# Altium schematic sheet 02_CORE.SchDoc
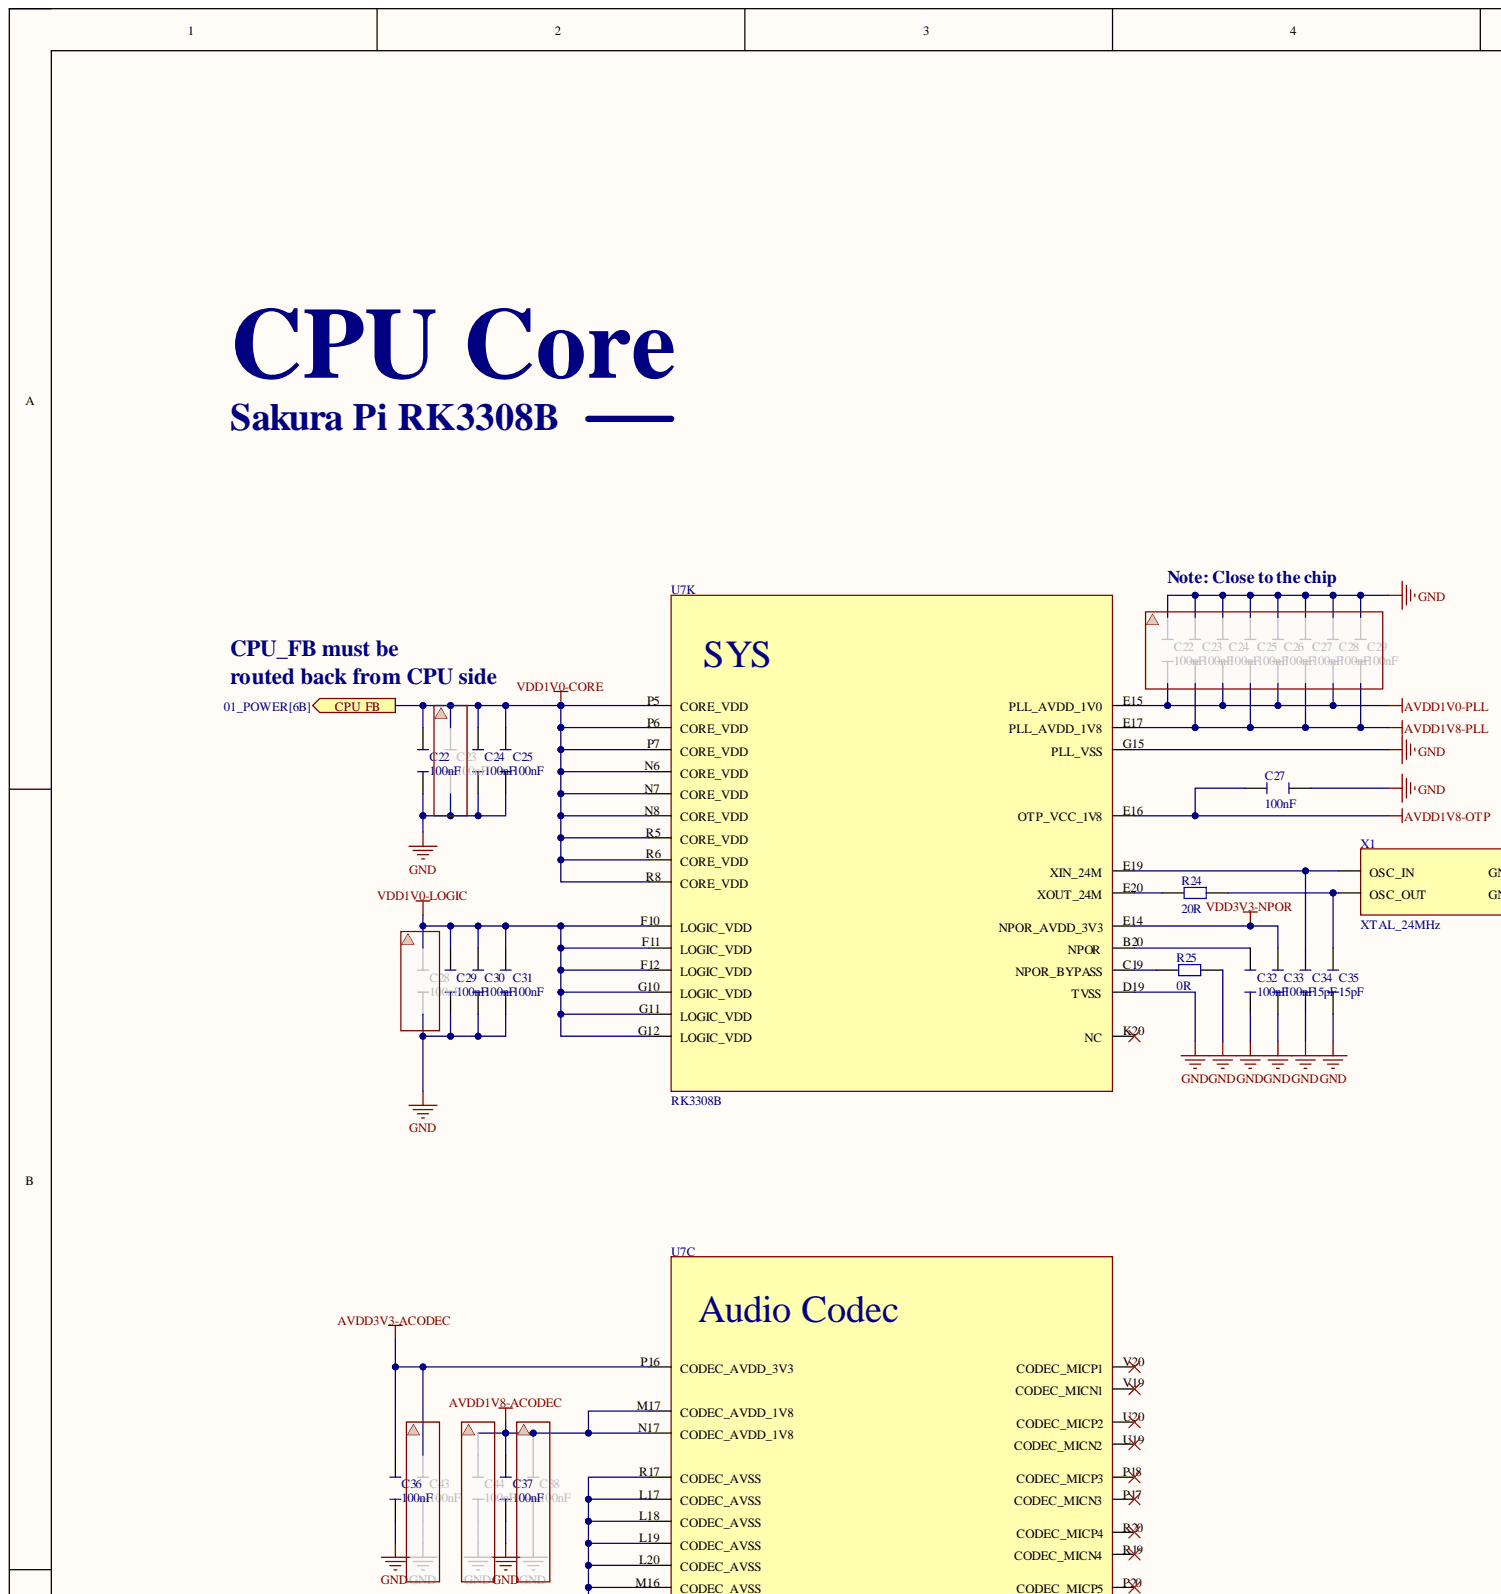
[diagram p0: 02_CORE.SchDoc, top left]
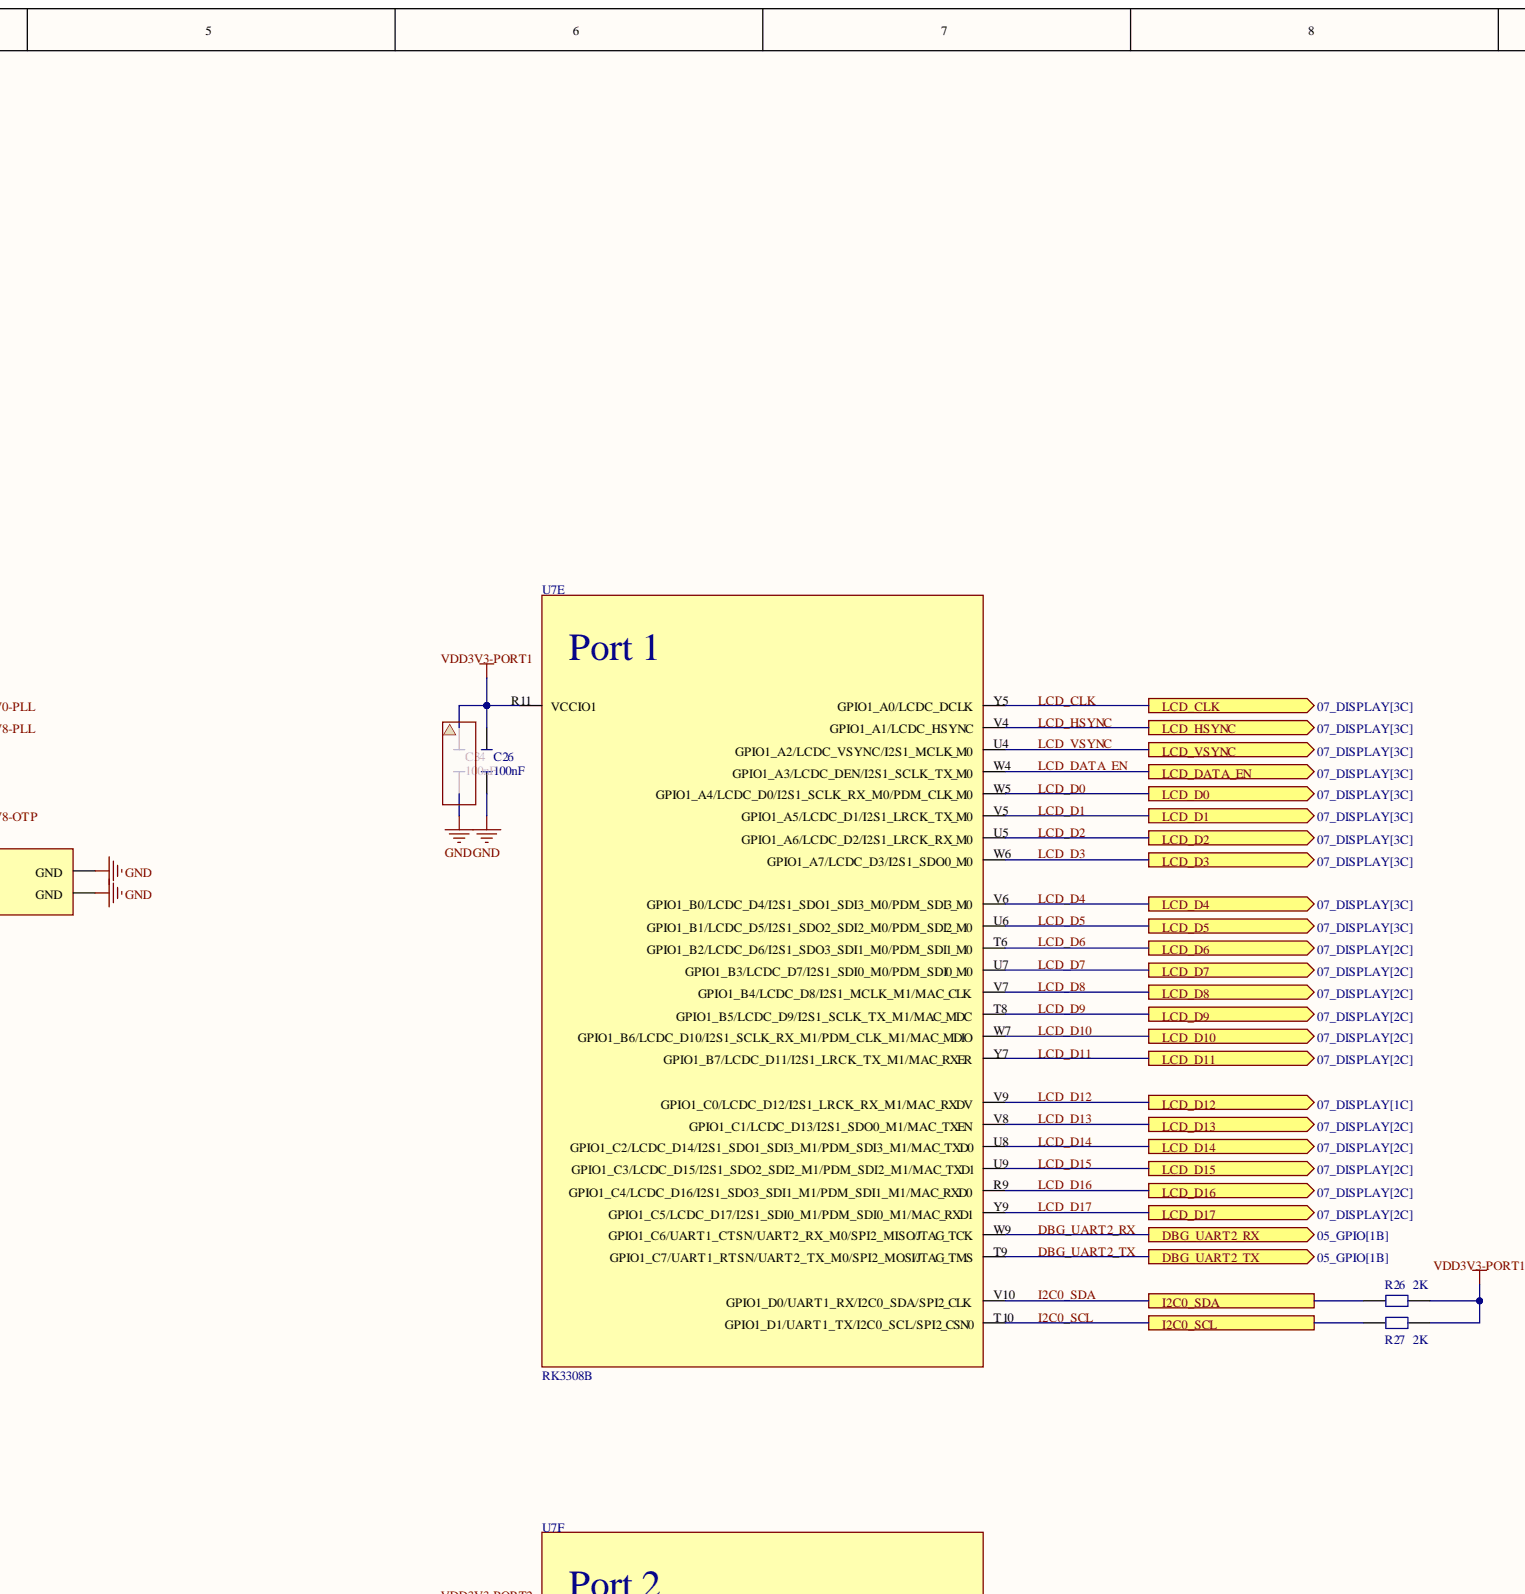
[diagram p1: 02_CORE.SchDoc, top centre]
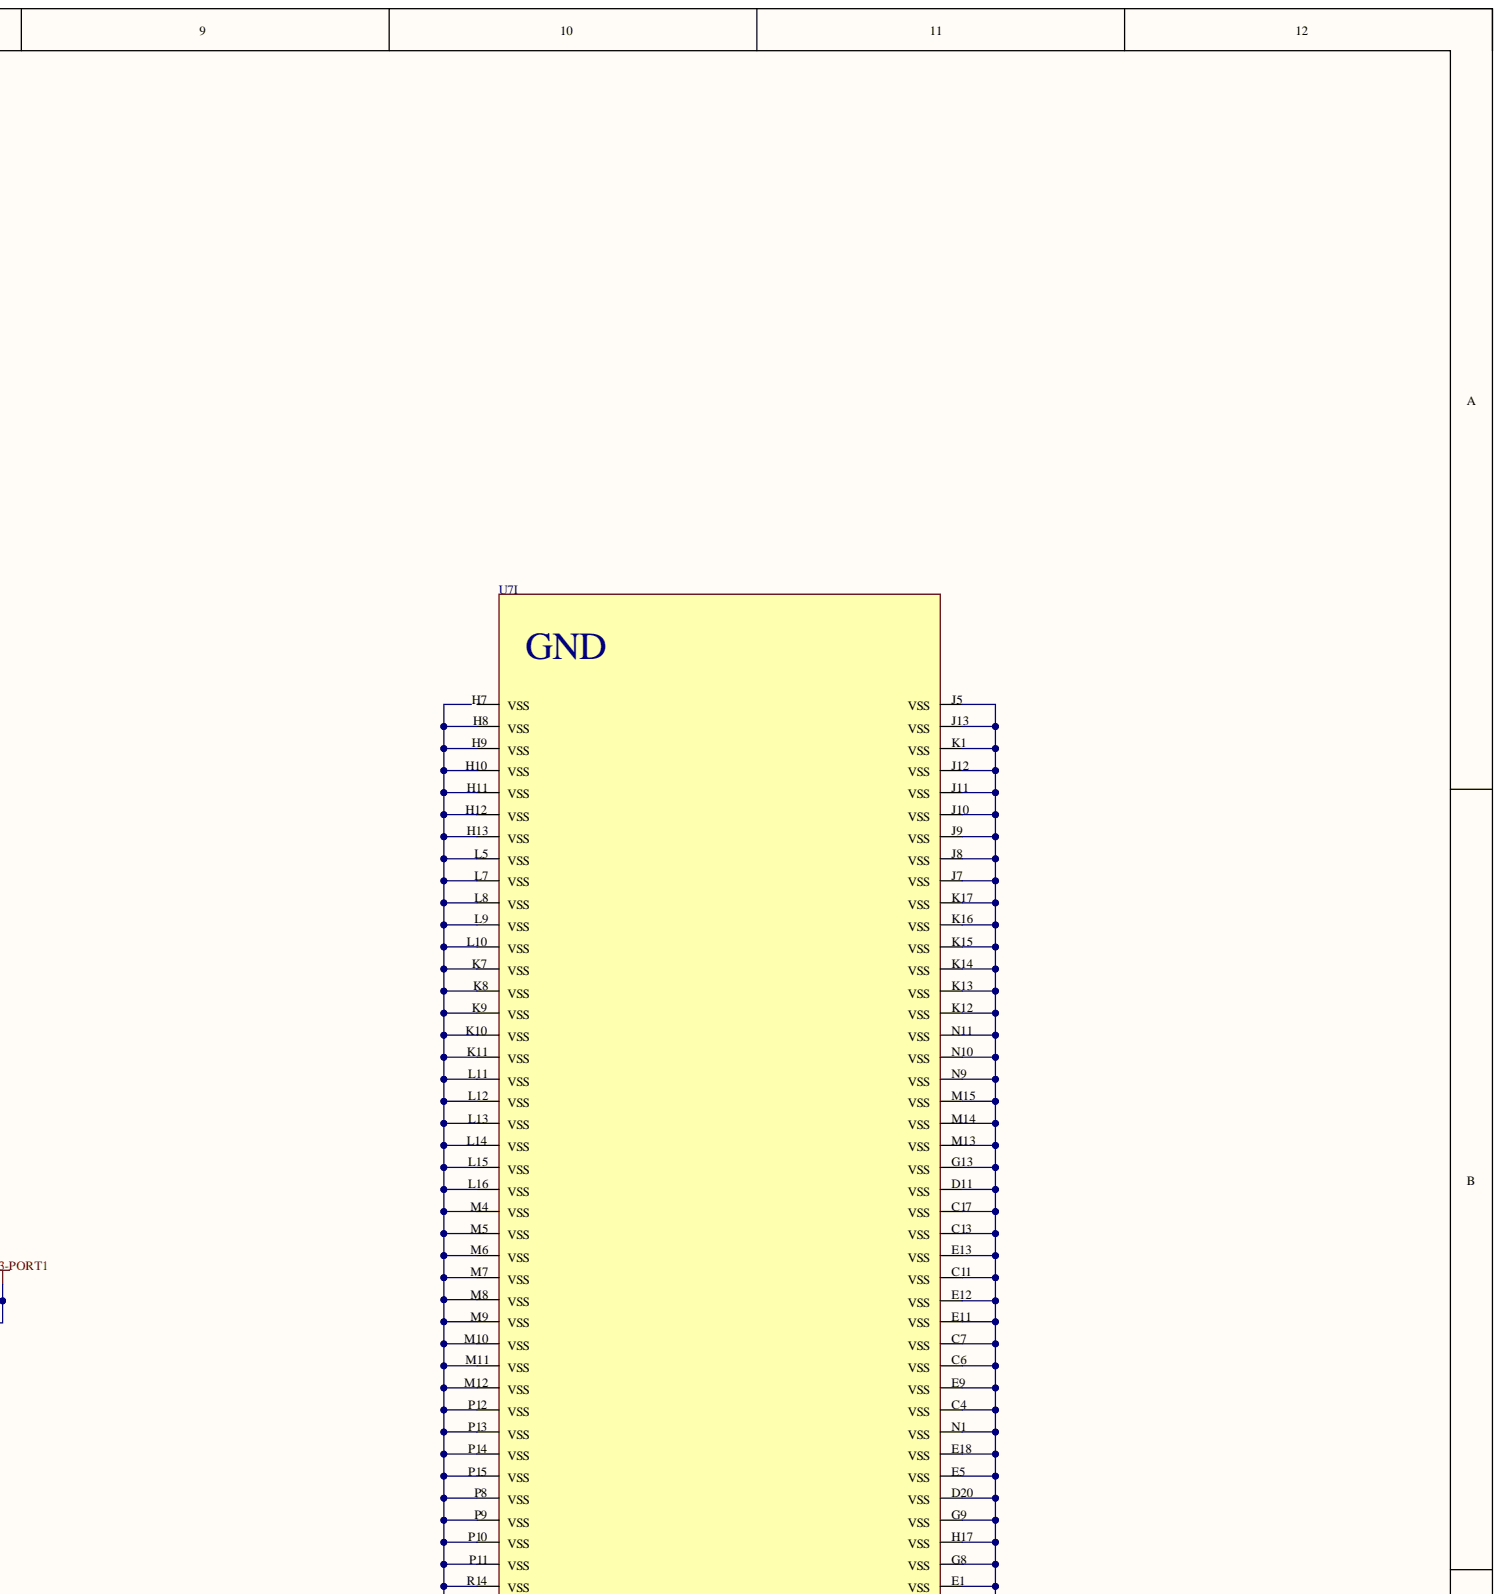
[diagram p2: 02_CORE.SchDoc, top right]
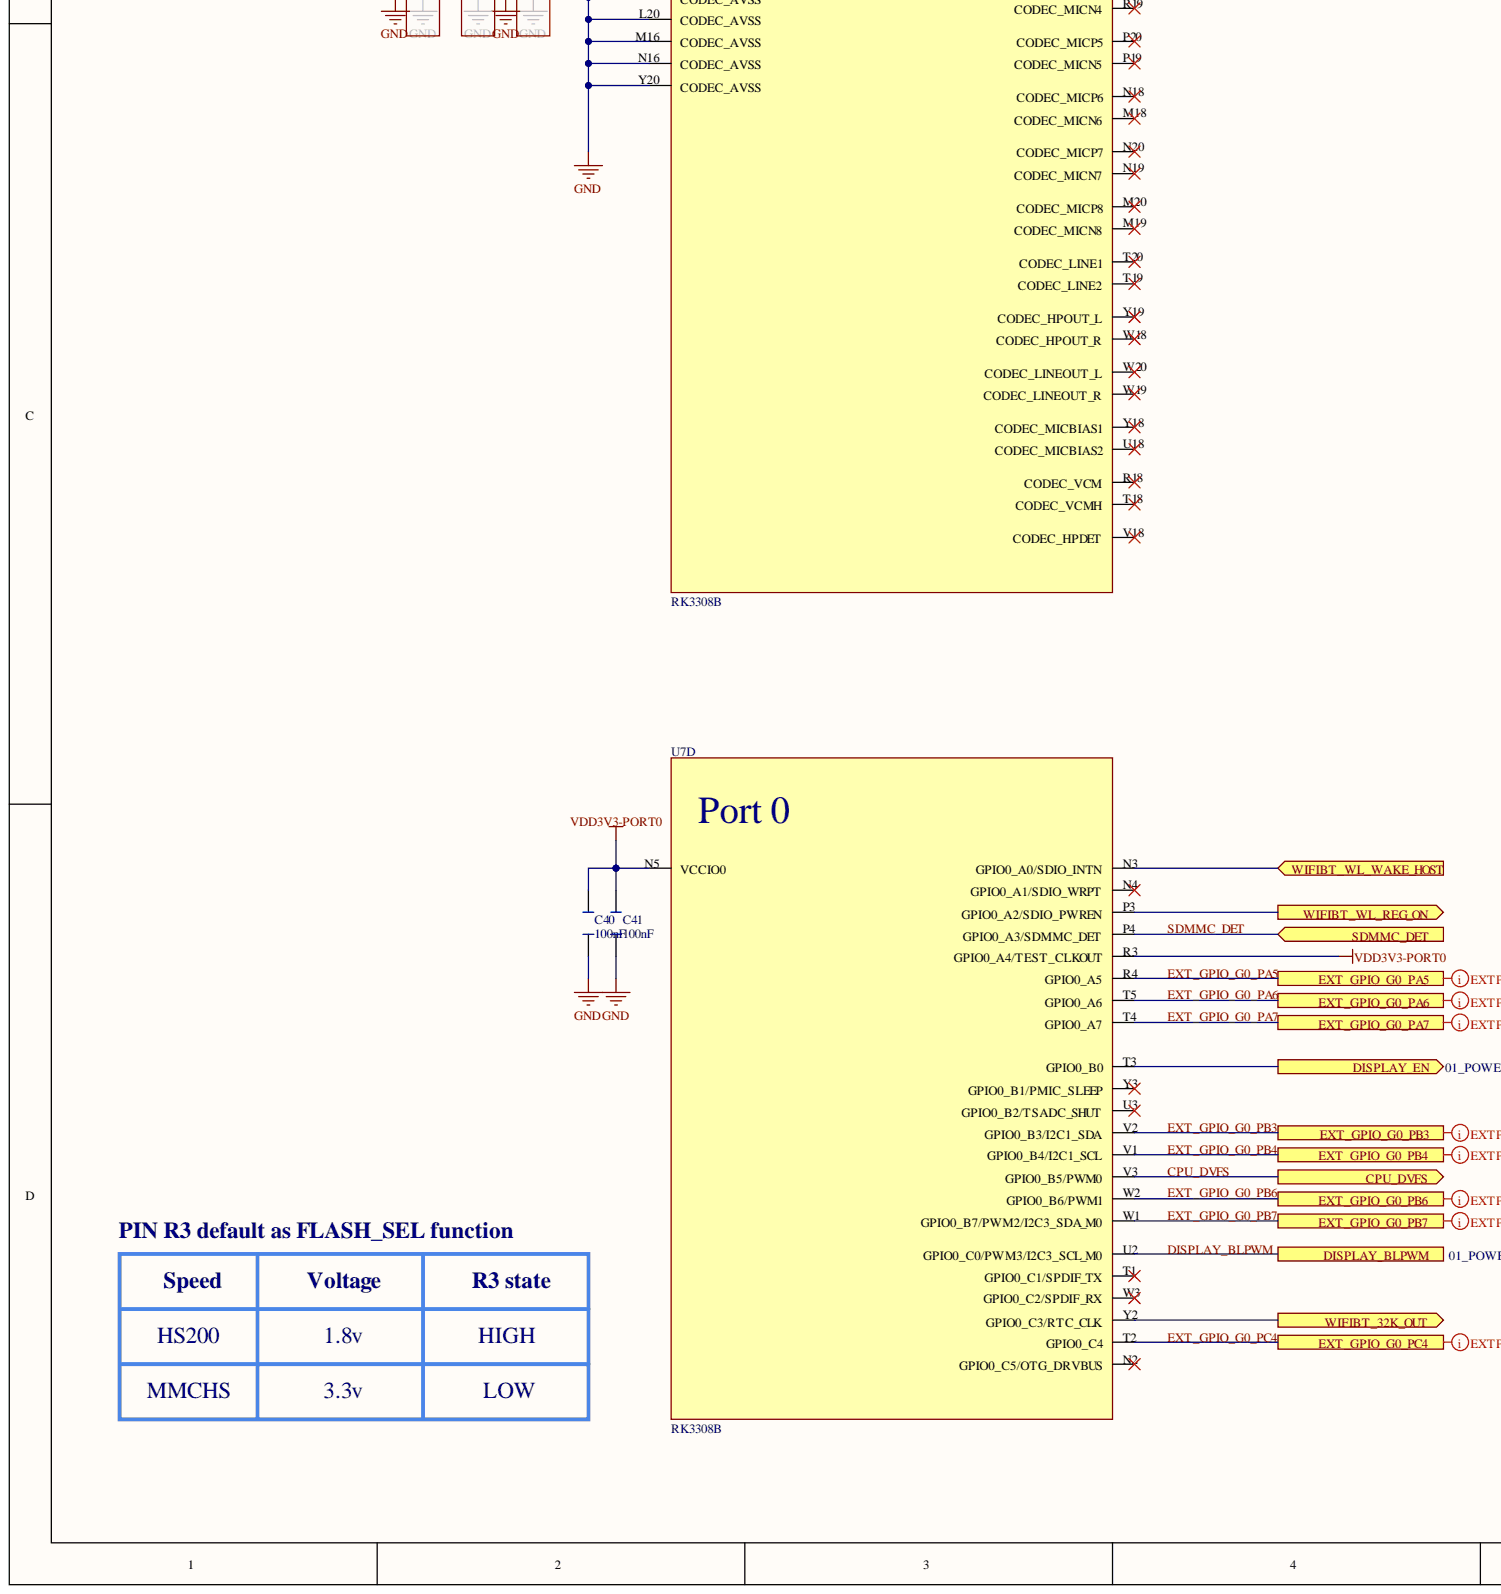
[diagram p3: 02_CORE.SchDoc, bottom left]
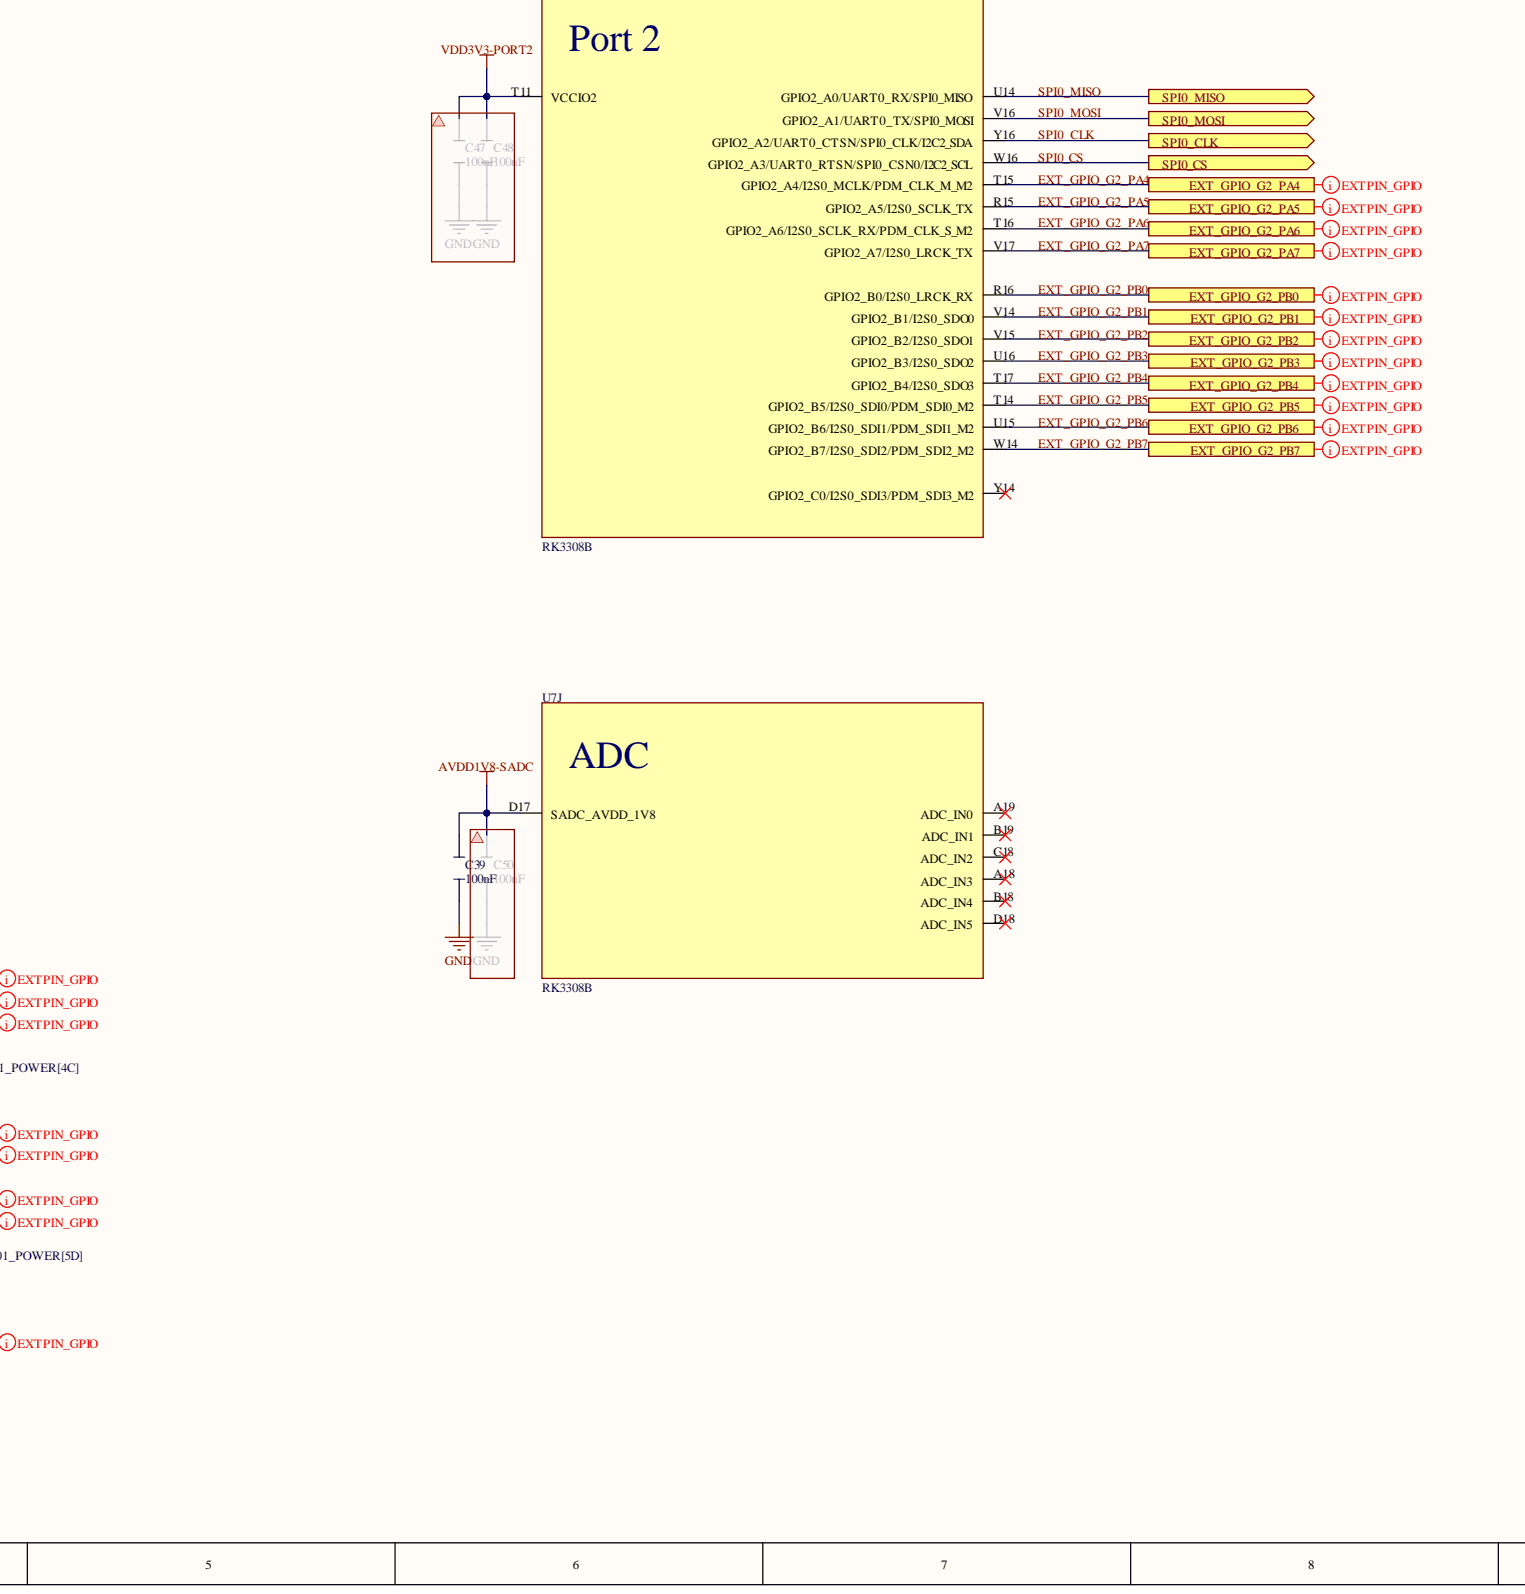
[diagram p4: 02_CORE.SchDoc, bottom centre]
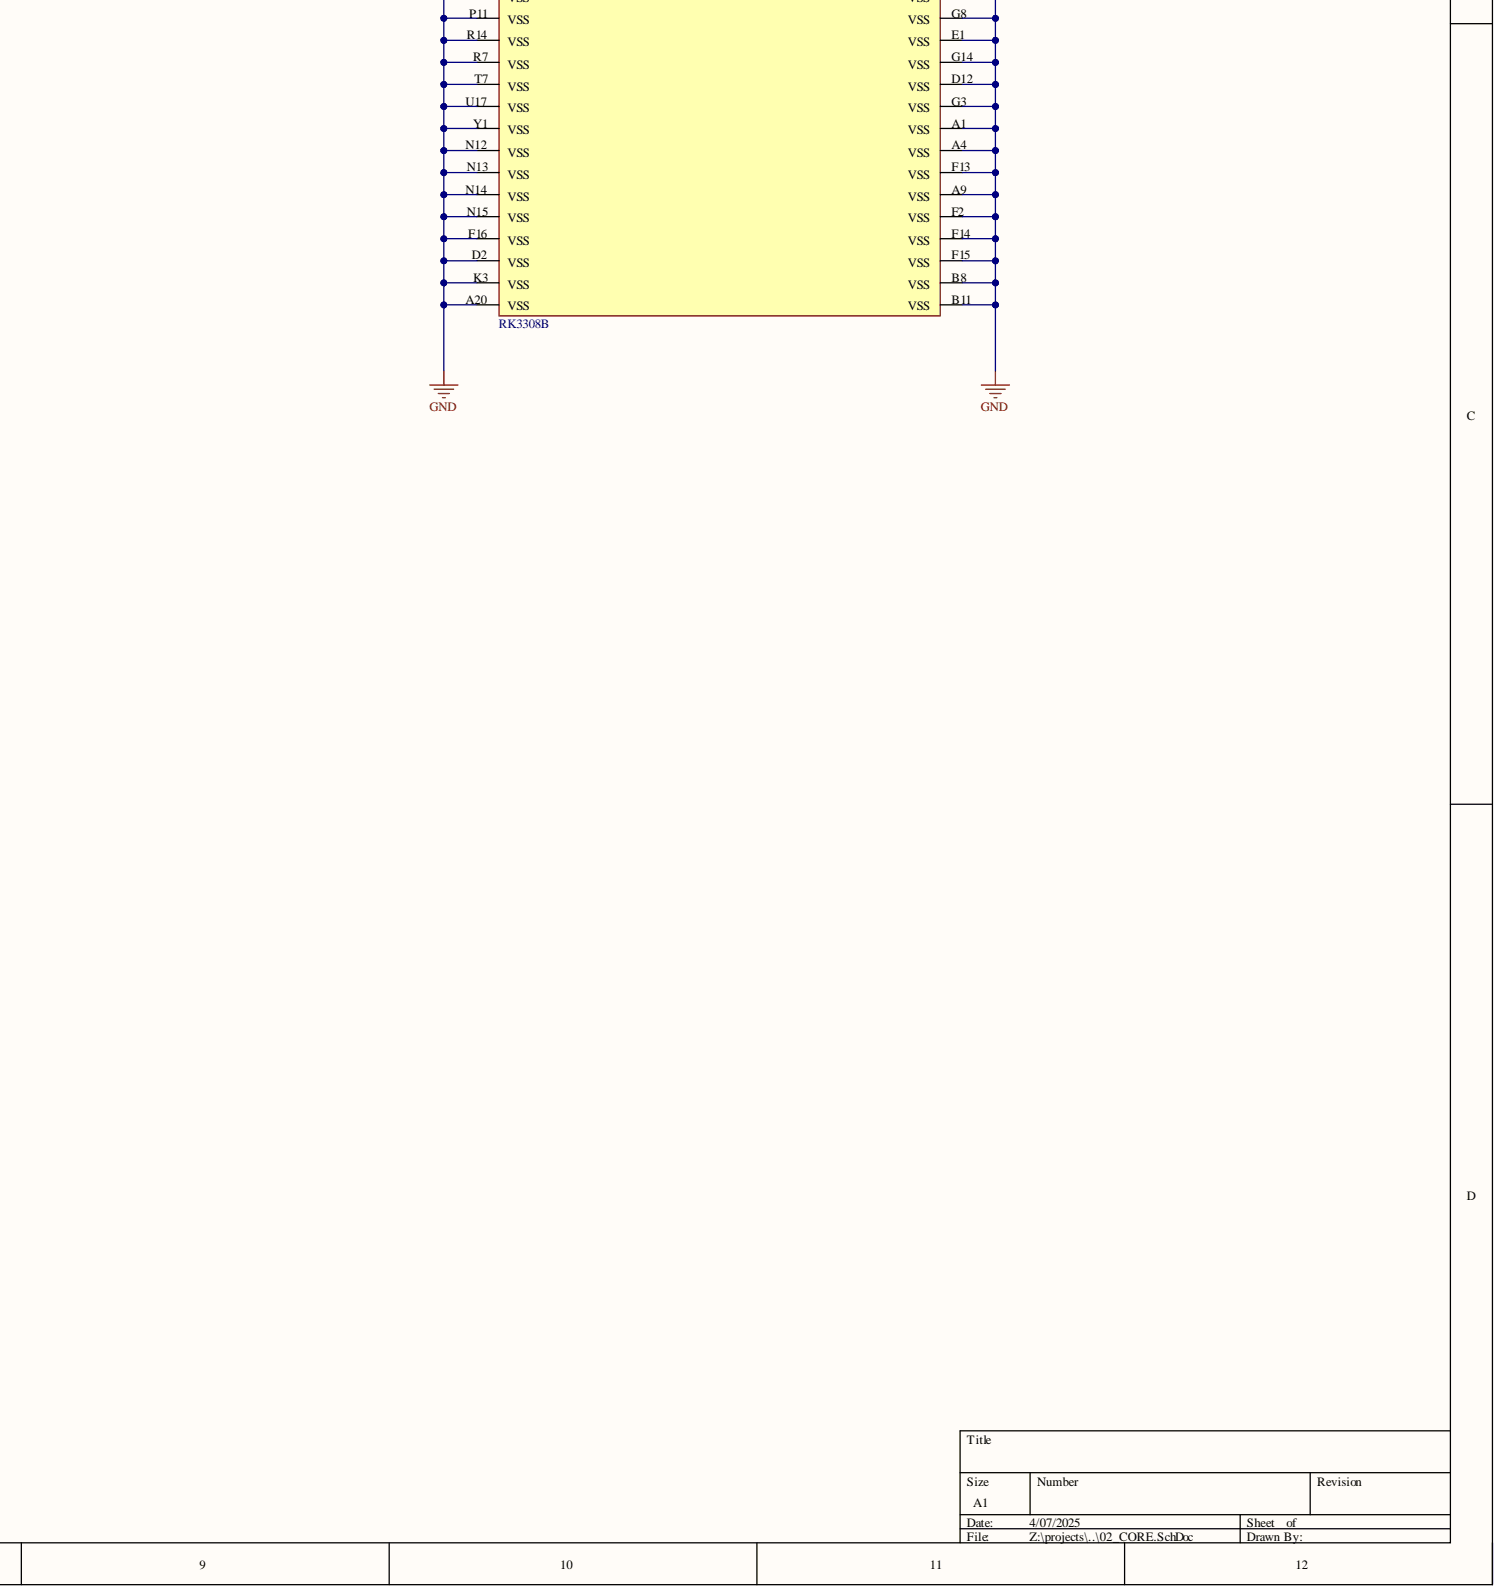
[diagram p5: 02_CORE.SchDoc, bottom right]

## Parts
C22  100nF  [C0402]
C24  100nF  [C0402]
C25  100nF  [C0402]
C26  100nF  [C0402]
C27  100nF  [C0402]
C29  100nF  [C0402]
C30  100nF  [C0402]
C31  100nF  [C0402]
C32  100nF  [C0402]
C33  100nF  [C0402]
C34  15pF  [C0402]
C35  15pF  [C0402]
C36  100nF  [C0402]
C37  100nF  [C0402]
C39  100nF  [C0402]
C40  100nF  [C0402]
C41  100nF  [C0402]
R24  20R  [R0402]
R25  0R  [R0402]
R26  2K  [R0402]
R27  2K  [R0402]
U7  RK3308B  [RK3308B]  (parts C,D,E,F,I,J,K)
X1  XTAL_24MHz  [SMD2016]

## Ports
CPU_DVFS, CPU_FB, DBG_UART2_RX, DBG_UART2_TX, DISPLAY_BLPWM, DISPLAY_EN, EXT_GPIO_G0_PA5, EXT_GPIO_G0_PA6, EXT_GPIO_G0_PA7, EXT_GPIO_G0_PB3, EXT_GPIO_G0_PB4, EXT_GPIO_G0_PB6, EXT_GPIO_G0_PB7, EXT_GPIO_G0_PC4, EXT_GPIO_G2_PA4, EXT_GPIO_G2_PA5, EXT_GPIO_G2_PA6, EXT_GPIO_G2_PA7, EXT_GPIO_G2_PB0, EXT_GPIO_G2_PB1, EXT_GPIO_G2_PB2, EXT_GPIO_G2_PB3, EXT_GPIO_G2_PB4, EXT_GPIO_G2_PB5, EXT_GPIO_G2_PB6, EXT_GPIO_G2_PB7, I2C0_SCL, I2C0_SDA, LCD_CLK, LCD_D0, LCD_D1, LCD_D2, LCD_D3, LCD_D4, LCD_D5, LCD_D6, LCD_D7, LCD_D8, LCD_D9, LCD_D10, LCD_D11, LCD_D12, LCD_D13, LCD_D14, LCD_D15, LCD_D16, LCD_D17, LCD_DATA_EN, LCD_HSYNC, LCD_VSYNC, SDMMC_DET, SPI0_CLK, SPI0_CS, SPI0_MISO, SPI0_MOSI, WIFIBT_32K_OUT, WIFIBT_WL_REG_ON, WIFIBT_WL_WAKE_HOST

## Nets
AVDD1V0-PLL: U7.E15(PLL_AVDD_1V0)
AVDD1V8-ACODEC: C37.2 U7.M17(CODEC_AVDD_1V8) U7.N17(CODEC_AVDD_1V8)
AVDD1V8-OTP: C27.2 U7.E16(OTP_VCC_1V8)
AVDD1V8-PLL: U7.E17(PLL_AVDD_1V8)
AVDD1V8-SADC: C39.2 U7.D17(SADC_AVDD_1V8)
AVDD3V3-ACODEC: C36.2 U7.P16(CODEC_AVDD_3V3)
GND: C22.2 C24.2 C25.2 C26.1 C27.1 C29.2 C30.2 C31.2 C32.1 C33.2 C34.2 C35.2 C36.1 C37.1 C39.1 C40.1 C41.1 R25.2 U7.A1(VSS) U7.A4(VSS) U7.A9(VSS) U7.A20(VSS) U7.B8(VSS) U7.B11(VSS) U7.C4(VSS) U7.C6(VSS) U7.C7 U7.C11(VSS) U7.C13(VSS) U7.C17(VSS) U7.D2(VSS) U7.D11 U7.D12(VSS) U7.D19(TVSS) U7.D20(VSS) U7.E1(VSS) U7.E5(VSS) U7.E9(VSS) U7.E11(VSS) U7.E12(VSS) U7.E13(VSS) U7.E18(VSS) U7.F2(VSS) U7.F13(VSS) U7.F14(VSS) U7.F15(VSS) U7.F16(VSS) U7.G3(VSS) U7.G8(VSS) U7.G9(VSS) U7.G13(VSS) U7.G14(VSS) U7.G15(PLL_VSS) U7.H8(VSS) U7.H9(VSS) U7.H10(VSS) U7.H11(VSS) U7.H12(VSS) U7.H13(VSS) U7.H17(VSS) U7.J5 U7.J7 U7.J8 U7.J9(VSS) U7.J10(VSS) U7.J11(VSS) U7.J12(VSS) U7.J13(VSS) U7.K1(VSS) U7.K3(VSS) U7.K7(VSS) U7.K8(VSS) U7.K9(VSS) U7.K10 U7.K11(VSS) U7.K12(VSS) U7.K13(VSS) U7.K14(VSS) U7.K15(VSS) U7.K16(VSS) U7.K17(VSS) U7.L5 U7.L7 U7.L8(VSS) U7.L9(VSS) U7.L10(VSS) U7.L11(VSS) U7.L12(VSS) U7.L13(VSS) U7.L14(VSS) U7.L15(VSS) U7.L16 U7.L17(CODEC_AVSS) U7.L18(CODEC_AVSS) U7.L19(CODEC_AVSS) U7.L20(CODEC_AVSS) U7.M4(VSS) U7.M5(VSS) U7.M6(VSS) U7.M7(VSS) U7.M8(VSS) U7.M9(VSS) U7.M10 U7.M11(VSS) U7.M12(VSS) U7.M13(VSS) U7.M14(VSS) U7.M15(VSS) U7.M16(CODEC_AVSS) U7.N1(VSS) U7.N9(VSS) U7.N10(VSS) U7.N11 U7.N12(VSS) U7.N13(VSS) U7.N14(VSS) U7.N15(VSS) U7.N16(CODEC_AVSS) U7.P8(VSS) U7.P9(VSS) U7.P10(VSS) U7.P11(VSS) U7.P12(VSS) U7.P13(VSS) U7.P14(VSS) U7.P15(VSS) U7.R7(VSS) U7.R14(VSS) U7.R17(CODEC_AVSS) U7.T7(VSS) U7.U17(VSS) U7.Y1(VSS) U7.Y20(CODEC_AVSS) X1.2(GND) X1.4(GND)
VDD1V0-LOGIC: C29.1 C30.1 C31.1 U7.F10(LOGIC_VDD) U7.F11(LOGIC_VDD) U7.F12(LOGIC_VDD) U7.G10(LOGIC_VDD) U7.G11(LOGIC_VDD) U7.G12(LOGIC_VDD)
VDD3V3-NPOR: C33.1 U7.E14(NPOR_AVDD_3V3)
VDD3V3-PORT0: C40.2 C41.2 U7.N5(VCCIO0) U7.R3(GPIO0_A4/TEST_CLKOUT)
VDD3V3-PORT1: C26.2 R26.2 R27.2 U7.R11(VCCIO1)
VDD3V3-PORT2: U7.T11(VCCIO2)
CPU_DVFS: U7.V3(GPIO0_B5/PWM0) ; port CPU_DVFS
DBG_UART2_RX: U7.W9(GPIO1_C6/UART1_CTSN/UART2_RX_M0/SPI2_MISO/JTAG_TCK) ; port DBG_UART2_RX
DBG_UART2_TX: U7.T9(GPIO1_C7/UART1_RTSN/UART2_TX_M0/SPI2_MOSI/JTAG_TMS) ; port DBG_UART2_TX
DISPLAY_BLPWM: U7.U2(GPIO0_C0/PWM3/I2C3_SCL_M0) ; port DISPLAY_BLPWM
DISPLAY_EN: U7.T3(GPIO0_B0) ; port DISPLAY_EN
EXT_GPIO_G0_PA5: U7.R4(GPIO0_A5) ; port EXT_GPIO_G0_PA5
EXT_GPIO_G0_PA6: U7.T5(GPIO0_A6) ; port EXT_GPIO_G0_PA6
EXT_GPIO_G0_PA7: U7.T4(GPIO0_A7) ; port EXT_GPIO_G0_PA7
EXT_GPIO_G0_PB3: U7.V2(GPIO0_B3/I2C1_SDA) ; port EXT_GPIO_G0_PB3
EXT_GPIO_G0_PB4: U7.V1(GPIO0_B4/I2C1_SCL) ; port EXT_GPIO_G0_PB4
EXT_GPIO_G0_PB6: U7.W2(GPIO0_B6/PWM1) ; port EXT_GPIO_G0_PB6
EXT_GPIO_G0_PB7: U7.W1(GPIO0_B7/PWM2/I2C3_SDA_M0) ; port EXT_GPIO_G0_PB7
EXT_GPIO_G0_PC4: U7.T2(GPIO0_C4) ; port EXT_GPIO_G0_PC4
EXT_GPIO_G2_PA4: U7.T15(GPIO2_A4/I2S0_MCLK/PDM_CLK_M_M2) ; port EXT_GPIO_G2_PA4
EXT_GPIO_G2_PA5: U7.R15(GPIO2_A5/I2S0_SCLK_TX) ; port EXT_GPIO_G2_PA5
EXT_GPIO_G2_PA6: U7.T16(GPIO2_A6/I2S0_SCLK_RX/PDM_CLK_S_M2) ; port EXT_GPIO_G2_PA6
EXT_GPIO_G2_PA7: U7.V17(GPIO2_A7/I2S0_LRCK_TX) ; port EXT_GPIO_G2_PA7
EXT_GPIO_G2_PB0: U7.R16(GPIO2_B0/I2S0_LRCK_RX) ; port EXT_GPIO_G2_PB0
EXT_GPIO_G2_PB1: U7.V14(GPIO2_B1/I2S0_SDO0) ; port EXT_GPIO_G2_PB1
EXT_GPIO_G2_PB2: U7.V15(GPIO2_B2/I2S0_SDO1) ; port EXT_GPIO_G2_PB2
EXT_GPIO_G2_PB3: U7.U16(GPIO2_B3/I2S0_SDO2) ; port EXT_GPIO_G2_PB3
EXT_GPIO_G2_PB4: U7.T17(GPIO2_B4/I2S0_SDO3) ; port EXT_GPIO_G2_PB4
EXT_GPIO_G2_PB5: U7.T14(GPIO2_B5/I2S0_SDI0/PDM_SDI0_M2) ; port EXT_GPIO_G2_PB5
EXT_GPIO_G2_PB6: U7.U15(GPIO2_B6/I2S0_SDI1/PDM_SDI1_M2) ; port EXT_GPIO_G2_PB6
EXT_GPIO_G2_PB7: U7.W14(GPIO2_B7/I2S0_SDI2/PDM_SDI2_M2) ; port EXT_GPIO_G2_PB7
I2C0_SCL: R27.1 U7.T10(GPIO1_D1/UART1_TX/I2C0_SCL/SPI2_CSN0) ; port I2C0_SCL
I2C0_SDA: R26.1 U7.V10(GPIO1_D0/UART1_RX/I2C0_SDA/SPI2_CLK) ; port I2C0_SDA
LCD_CLK: U7.Y5(GPIO1_A0/LCDC_DCLK) ; port LCD_CLK
LCD_D0: U7.W5(GPIO1_A4/LCDC_D0/I2S1_SCLK_RX_M0/PDM_CLK_M0) ; port LCD_D0
LCD_D1: U7.V5(GPIO1_A5/LCDC_D1/I2S1_LRCK_TX_M0) ; port LCD_D1
LCD_D2: U7.U5 ; port LCD_D2
LCD_D3: U7.W6(GPIO1_A7/LCDC_D3/I2S1_SDO0_M0) ; port LCD_D3
LCD_D4: U7.V6(GPIO1_B0/LCDC_D4/I2S1_SDO1_SDI3_M0/PDM_SDI3_M0) ; port LCD_D4
LCD_D5: U7.U6(GPIO1_B1/LCDC_D5/I2S1_SDO2_SDI2_M0/PDM_SDI2_M0) ; port LCD_D5
LCD_D6: U7.T6(GPIO1_B2/LCDC_D6/I2S1_SDO3_SDI1_M0/PDM_SDI1_M0) ; port LCD_D6
LCD_D7: U7.U7(GPIO1_B3/LCDC_D7/I2S1_SDI0_M0/PDM_SDI0_M0) ; port LCD_D7
LCD_D8: U7.V7(GPIO1_B4/LCDC_D8/I2S1_MCLK_M1/MAC_CLK) ; port LCD_D8
LCD_D9: U7.T8(GPIO1_B5/LCDC_D9/I2S1_SCLK_TX_M1/MAC_MDC) ; port LCD_D9
LCD_D10: U7.W7(GPIO1_B6/LCDC_D10/I2S1_SCLK_RX_M1/PDM_CLK_M1/MAC_MDIO) ; port LCD_D10
LCD_D11: U7.Y7(GPIO1_B7/LCDC_D11/I2S1_LRCK_TX_M1/MAC_RXER) ; port LCD_D11
LCD_D12: U7.V9(GPIO1_C0/LCDC_D12/I2S1_LRCK_RX_M1/MAC_RXDV) ; port LCD_D12
LCD_D13: U7.V8(GPIO1_C1/LCDC_D13/I2S1_SDO0_M1/MAC_TXEN) ; port LCD_D13
LCD_D14: U7.U8(GPIO1_C2/LCDC_D14/I2S1_SDO1_SDI3_M1/PDM_SDI3_M1/MAC_TXD0) ; port LCD_D14
LCD_D15: U7.U9(GPIO1_C3/LCDC_D15/I2S1_SDO2_SDI2_M1/PDM_SDI2_M1/MAC_TXD1) ; port LCD_D15
LCD_D16: U7.R9(GPIO1_C4/LCDC_D16/I2S1_SDO3_SDI1_M1/PDM_SDI1_M1/MAC_RXD0) ; port LCD_D16
LCD_D17: U7.Y9(GPIO1_C5/LCDC_D17/I2S1_SDI0_M1/PDM_SDI0_M1/MAC_RXD1) ; port LCD_D17
LCD_DATA_EN: U7.W4(GPIO1_A3/LCDC_DEN/I2S1_SCLK_TX_M0) ; port LCD_DATA_EN
LCD_HSYNC: U7.V4(GPIO1_A1/LCDC_HSYNC) ; port LCD_HSYNC
LCD_VSYNC: U7.U4(GPIO1_A2/LCDC_VSYNC/I2S1_MCLK_M0) ; port LCD_VSYNC
SDMMC_DET: U7.P4(GPIO0_A3/SDMMC_DET) ; port SDMMC_DET
SPI0_CLK: U7.Y16(GPIO2_A2/UART0_CTSN/SPI0_CLK/I2C2_SDA) ; port SPI0_CLK
SPI0_CS: U7.W16(GPIO2_A3/UART0_RTSN/SPI0_CSN0/I2C2_SCL) ; port SPI0_CS
SPI0_MISO: U7.U14(GPIO2_A0/UART0_RX/SPI0_MISO) ; port SPI0_MISO
SPI0_MOSI: U7.V16(GPIO2_A1/UART0_TX/SPI0_MOSI) ; port SPI0_MOSI
VDD1V0-CORE: C22.1 C24.1 C25.1 U7.N6(CORE_VDD) U7.N7(CORE_VDD) U7.N8(CORE_VDD) U7.P5(CORE_VDD) U7.P6(CORE_VDD) U7.P7(CORE_VDD) U7.R5 U7.R6(CORE_VDD) U7.R8(CORE_VDD) ; port CPU_FB
WIFIBT_32K_OUT: U7.Y2(GPIO0_C3/RTC_CLK) ; port WIFIBT_32K_OUT
WIFIBT_WL_REG_ON: U7.P3(GPIO0_A2/SDIO_PWREN) ; port WIFIBT_WL_REG_ON
WIFIBT_WL_WAKE_HOST: U7.N3(GPIO0_A0/SDIO_INTN) ; port WIFIBT_WL_WAKE_HOST
NetC32_2: C32.2 U7.B20(NPOR)
NetC34_1: C34.1 U7.E19(XIN_24M) X1.1(OSC_IN)
NetC35_1: C35.1 R24.2 X1.3(OSC_OUT)
NetR24_1: R24.1 U7.E20(XOUT_24M)
NetR25_1: R25.1 U7.C19(NPOR_BYPASS)

Not connected: U7 A18(ADC_IN3) A19(ADC_IN0) B18(ADC_IN4) B19(ADC_IN1) C18(ADC_IN2) D18(ADC_IN5) H7 K20(NC) M18(CODEC_MICN6) M19(CODEC_MICN8) M20(CODEC_MICP8) N2(GPIO0_C5/OTG_DRVBUS) N4(GPIO0_A1/SDIO_WRPT) N18(CODEC_MICP6) N19(CODEC_MICN7) N20(CODEC_MICP7) P17(CODEC_MICN3) P18(CODEC_MICP3) P19(CODEC_MICN5) P20(CODEC_MICP5) R18(CODEC_VCM) R19(CODEC_MICN4) R20(CODEC_MICP4) T1(GPIO0_C1/SPDIF_TX) T18(CODEC_VCMH) T19(CODEC_LINE2) T20(CODEC_LINE1) U3(GPIO0_B2/TSADC_SHUT) U18(CODEC_MICBIAS2) U19(CODEC_MICN2) U20(CODEC_MICP2) V18(CODEC_HPDET) V19(CODEC_MICN1) V20(CODEC_MICP1) W3(GPIO0_C2/SPDIF_RX) W18(CODEC_HPOUT_R) W19(CODEC_LINEOUT_R) W20(CODEC_LINEOUT_L) Y3(GPIO0_B1/PMIC_SLEEP) Y14(GPIO2_C0/I2S0_SDI3/PDM_SDI3_M2) Y18(CODEC_MICBIAS1) Y19(CODEC_HPOUT_L)

## Library data of the parts
C22 C24-C27 C29-C33 C36 C37 C39-C41 = C_100NF: Component Kind: Standard; Library Name: rk3308b.SchLib
C34 C35 = C_15PF: Component Kind: Standard; Library Name: rk3308b.SchLib
R24 = R_20R: Component Kind: Standard; Library Name: rk3308b.SchLib
R25 = R_0R: Component Kind: Standard; Library Name: rk3308b.SchLib
R26 R27 = R_2K: Component Kind: Standard; Library Name: rk3308b.SchLib
U7 = RK3308B: Component Kind: Standard; Library Name: rk3308b.SchLib
X1 = XTAL_24MHz: Component Kind: Standard; Library Name: rk3308b.SchLib
